# Altium schematic sheet 11_PowerSupply.SchDoc
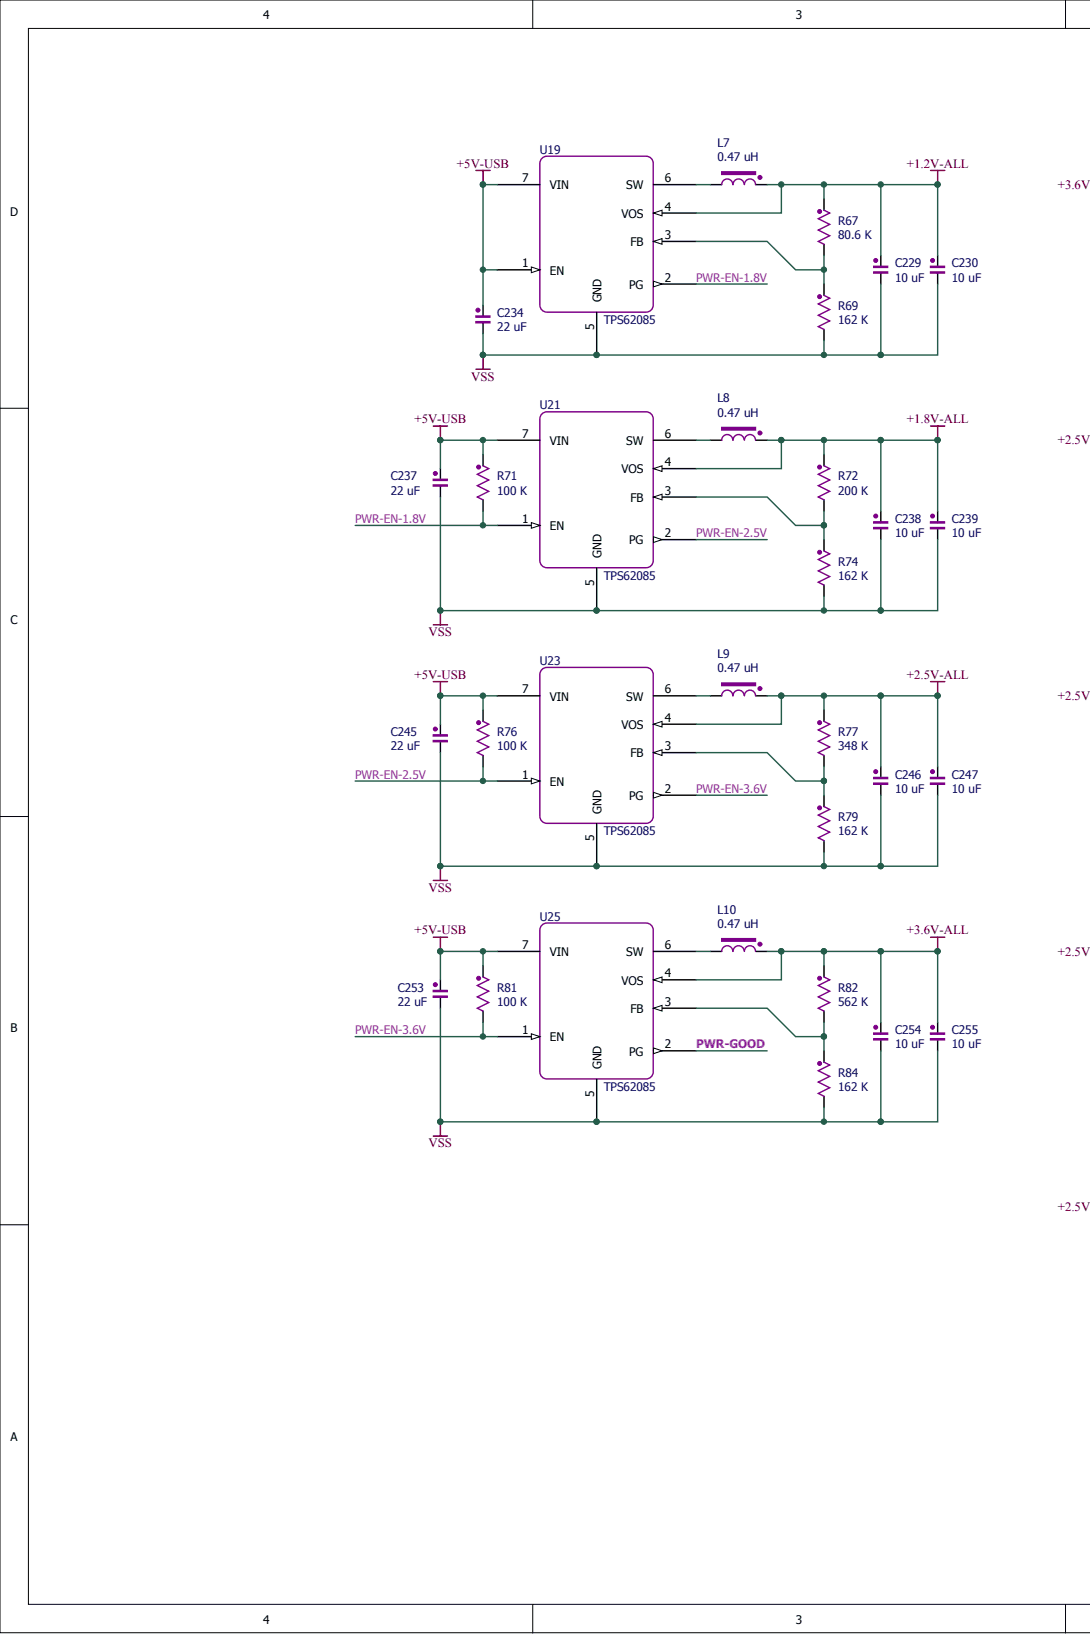
[diagram p0: 11_PowerSupply.SchDoc, left]
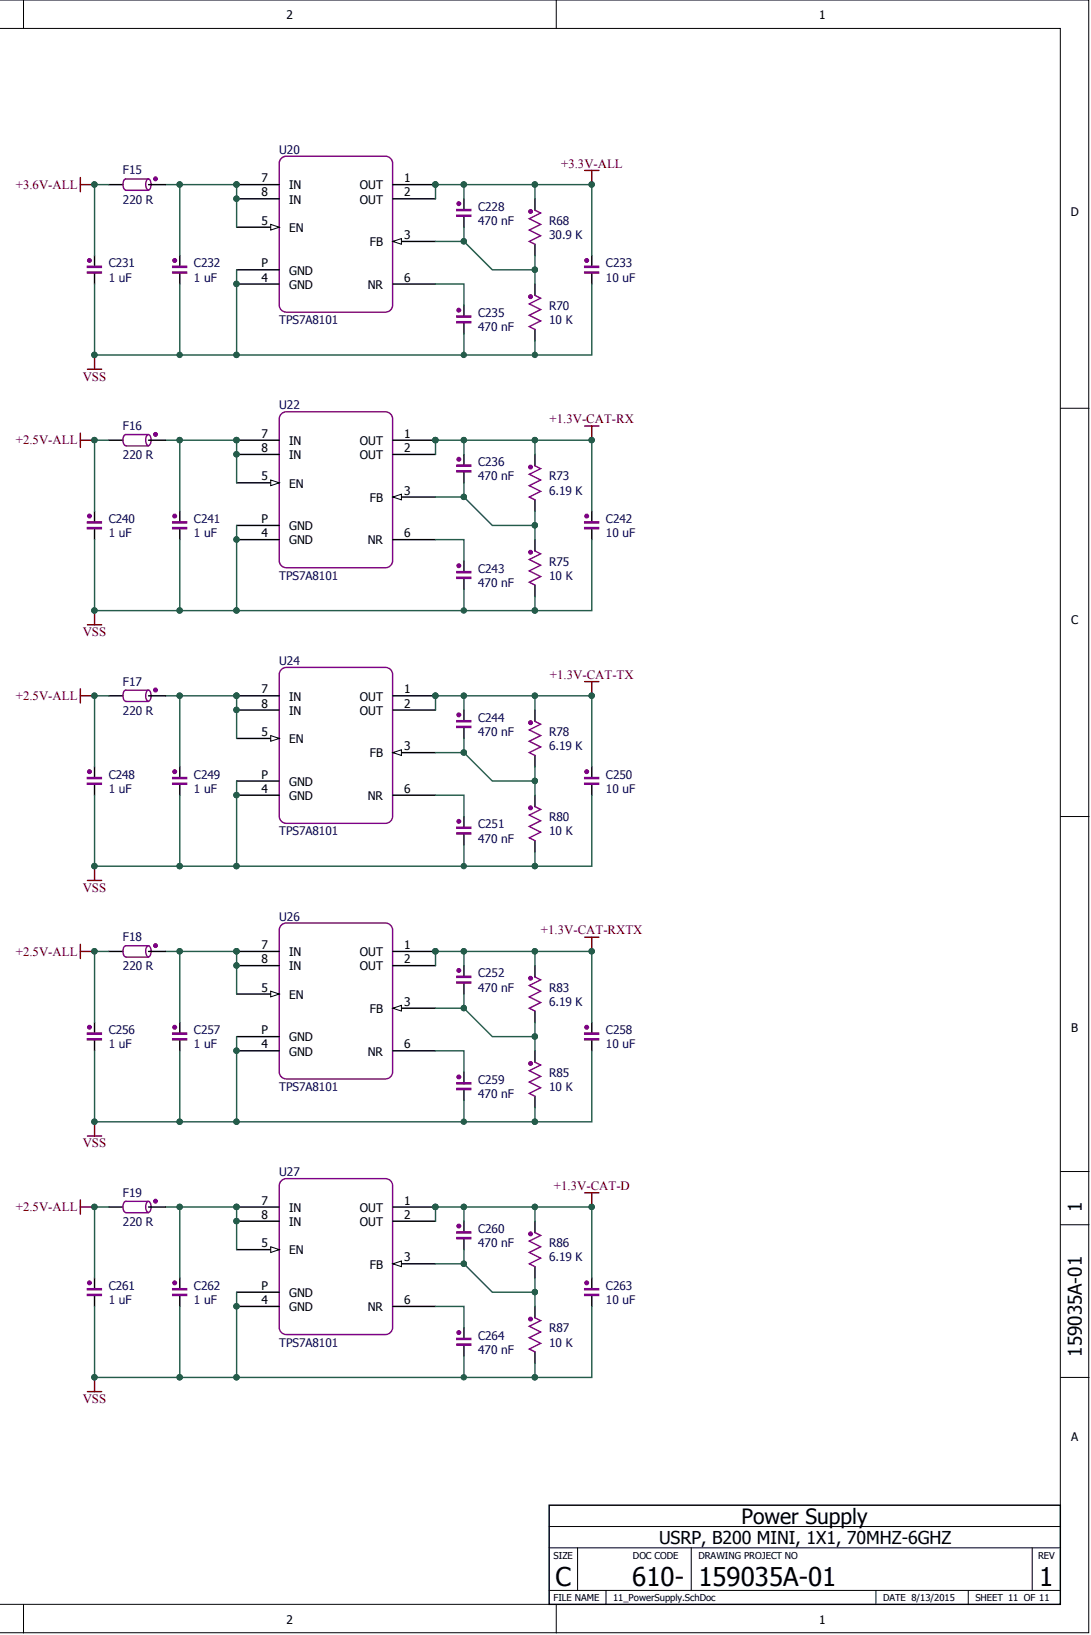
[diagram p1: 11_PowerSupply.SchDoc, right]

## Parts
C228  470 nF  [0201-CAP]
C229  10 uF  [0603-CAP]
C230  10 uF  [0603-CAP]
C231  1 uF  [0402-CAP]
C232  1 uF  [0402-CAP]
C233  10 uF  [0402-CAP]
C234  22 uF  [0805-CAP-HC]
C235  470 nF  [0201-CAP]
C236  470 nF  [0201-CAP]
C237  22 uF  [0805-CAP-HC]
C238  10 uF  [0603-CAP]
C239  10 uF  [0603-CAP]
C240  1 uF  [0402-CAP]
C241  1 uF  [0402-CAP]
C242  10 uF  [0402-CAP]
C243  470 nF  [0201-CAP]
C244  470 nF  [0201-CAP]
C245  22 uF  [0805-CAP-HC]
C246  10 uF  [0603-CAP]
C247  10 uF  [0603-CAP]
C248  1 uF  [0402-CAP]
C249  1 uF  [0402-CAP]
C250  10 uF  [0402-CAP]
C251  470 nF  [0201-CAP]
C252  470 nF  [0201-CAP]
C253  22 uF  [0805-CAP-HC]
C254  10 uF  [0603-CAP]
C255  10 uF  [0603-CAP]
C256  1 uF  [0402-CAP]
C257  1 uF  [0402-CAP]
C258  10 uF  [0402-CAP]
C259  470 nF  [0201-CAP]
C260  470 nF  [0201-CAP]
C261  1 uF  [0402-CAP]
C262  1 uF  [0402-CAP]
C263  10 uF  [0402-CAP]
C264  470 nF  [0201-CAP]
F15  220 R  [0402-BEAD]
F16  220 R  [0402-BEAD]
F17  220 R  [0402-BEAD]
F18  220 R  [0402-BEAD]
F19  220 R  [0402-BEAD]
L7  0.47 uH  [VISHAY-IHLP]
L8  0.47 uH  [VISHAY-IHLP]
L9  0.47 uH  [VISHAY-IHLP]
L10  0.47 uH  [VISHAY-IHLP]
R67  80.6 K  [0201-RES]
R68  30.9 K  [0201-RES]
R69  162 K  [0201-RES]
R70  10 K  [0201-RES]
R71  100 K  [0201-RES]
R72  200 K  [0201-RES]
R73  6.19 K  [0201-RES]
R74  162 K  [0201-RES]
R75  10 K  [0201-RES]
R76  100 K  [0201-RES]
R77  348 K  [0201-RES]
R78  6.19 K  [0201-RES]
R79  162 K  [0201-RES]
R80  10 K  [0201-RES]
R81  100 K  [0201-RES]
R82  562 K  [0201-RES]
R83  6.19 K  [0201-RES]
R84  162 K  [0201-RES]
R85  10 K  [0201-RES]
R86  6.19 K  [0201-RES]
R87  10 K  [0201-RES]
U19  TPS62085  [TI-VSON-7]
U20  TPS7A8101  [TI-SON-8]
U21  TPS62085  [TI-VSON-7]
U22  TPS7A8101  [TI-SON-8]
U23  TPS62085  [TI-VSON-7]
U24  TPS7A8101  [TI-SON-8]
U25  TPS62085  [TI-VSON-7]
U26  TPS7A8101  [TI-SON-8]
U27  TPS7A8101  [TI-SON-8]

## Nets
+1.2V-ALL: C229.2 C230.2 L7.2 R67.2 U19.4(VOS)
+1.3V-CAT-D: C260.2 C263.2 R86.2 U27.1(OUT) U27.2(OUT)
+1.3V-CAT-RX: C236.2 C242.2 R73.2 U22.1(OUT) U22.2(OUT)
+1.3V-CAT-RXTX: C252.2 C258.2 R83.2 U26.1(OUT) U26.2(OUT)
+1.3V-CAT-TX: C244.2 C250.2 R78.2 U24.1(OUT) U24.2(OUT)
+1.8V-ALL: C238.2 C239.2 L8.2 R72.2 U21.4(VOS)
+2.5V-ALL: C240.2 C246.2 C247.2 C248.2 C256.2 C261.2 F16.1 F17.1 F18.1 F19.1 L9.2 R77.2 U23.4(VOS)
+3.3V-ALL: C228.2 C233.2 R68.2 U20.1(OUT) U20.2(OUT)
+3.6V-ALL: C231.2 C254.2 C255.2 F15.1 L10.2 R82.2 U25.4(VOS)
+5V-USB: C234.2 C237.2 C245.2 C253.2 R71.2 R76.2 R81.2 U19.1(EN) U19.7(VIN) U21.7(VIN) U23.7(VIN) U25.7(VIN)
VSS: C229.1 C230.1 C231.1 C232.1 C233.1 C234.1 C235.1 C237.1 C238.1 C239.1 C240.1 C241.1 C242.1 C243.1 C245.1 C246.1 C247.1 C248.1 C249.1 C250.1 C251.1 C253.1 C254.1 C255.1 C256.1 C257.1 C258.1 C259.1 C261.1 C262.1 C263.1 C264.1 R69.1 R70.1 R74.1 R75.1 R79.1 R80.1 R84.1 R85.1 R87.1 U19.5(GND) U20.4(GND) U20.P(GND) U21.5(GND) U22.4(GND) U22.P(GND) U23.5(GND) U24.4(GND) U24.P(GND) U25.5(GND) U26.4(GND) U26.P(GND) U27.4(GND) U27.P(GND)
PWR-EN-1.8V: R71.1 U19.2(PG) U21.1(EN)
PWR-EN-2.5V: R76.1 U21.2(PG) U23.1(EN)
PWR-EN-3.6V: R81.1 U23.2(PG) U25.1(EN)
PWR-GOOD: U25.2(PG)
NetC228_1: C228.1 R68.1 R70.2 U20.3(FB)
NetC232_2: C232.2 F15.2 U20.5(EN) U20.7(IN) U20.8(IN)
NetC235_2: C235.2 U20.6(NR)
NetC236_1: C236.1 R73.1 R75.2 U22.3(FB)
NetC241_2: C241.2 F16.2 U22.5(EN) U22.7(IN) U22.8(IN)
NetC243_2: C243.2 U22.6(NR)
NetC244_1: C244.1 R78.1 R80.2 U24.3(FB)
NetC249_2: C249.2 F17.2 U24.5(EN) U24.7(IN) U24.8(IN)
NetC251_2: C251.2 U24.6(NR)
NetC252_1: C252.1 R83.1 R85.2 U26.3(FB)
NetC257_2: C257.2 F18.2 U26.5(EN) U26.7(IN) U26.8(IN)
NetC259_2: C259.2 U26.6(NR)
NetC260_1: C260.1 R86.1 R87.2 U27.3(FB)
NetC262_2: C262.2 F19.2 U27.5(EN) U27.7(IN) U27.8(IN)
NetC264_2: C264.2 U27.6(NR)
NetL7_1: L7.1 U19.6(SW)
NetL8_1: L8.1 U21.6(SW)
NetL9_1: L9.1 U23.6(SW)
NetL10_1: L10.1 U25.6(SW)
NetR67_1: R67.1 R69.2 U19.3(FB)
NetR72_1: R72.1 R74.2 U21.3(FB)
NetR77_1: R77.1 R79.2 U23.3(FB)
NetR82_1: R82.1 R84.2 U25.3(FB)

## Library data of the parts
C228 C235 C236 C243 C244 C251 C252 C259 C260 C264 = CAP: CAP, 470nF, X5R, 6.3V, ±10%, 0201, PbF; PartNumber: C0201X5R6R3-474KNP; Designer: shyul; PCBMarker: Capacitor; PinCountHole: 0; PinCountSMD: 2; Vendor: VENKEL; Component Kind: Standard; SerialNumber: 0; Value: 470 nF; Version: 1.0; VersionDate: 04-27-2011; Library Name: Basc_1_Passive.IntLib; Publisher: Cowin
C229 C230 C238 C239 C246 C247 C254 C255 = CAP: CAP,SM,10UF,6.3V,20%,X5R,CER,0603,H=.035IN; PartNumber: GRM188R60J106ME47D; Designer: shyul; PCBMarker: Capacitor; PinCountHole: 0; PinCountSMD: 2; SerialNumber: 716465-01; Vendor: muRata; Component Kind: Standard; Value: 10 uF; Version: 1.0; VersionDate: 04-27-2011; Library Name: Basc_1_Passive.IntLib; Publisher: Cowin
C231 C232 C240 C241 C248 C249 C256 C257 C261 C262 = CAP: CAP, 1uF, X5R, 10V, ±10%, 0402, PbF; PartNumber: C0402X5R100-105KNP; Designer: shyul; PCBMarker: Capacitor; PinCountHole: 0; PinCountSMD: 2; Vendor: VENKEL; Component Kind: Standard; SerialNumber: 0; Value: 1 uF; Version: 1.0; VersionDate: 04-27-2011; Library Name: Basc_1_Passive.IntLib; Publisher: Cowin
C233 C242 C250 C258 C263 = CAP: CAP, 10uF, X5R, 6.3V, ±20%, 0402, PbF; PartNumber: C0402X5R6R3-106MNP; Designer: shyul; PCBMarker: Capacitor; PinCountHole: 0; PinCountSMD: 2; Vendor: VENKEL; Component Kind: Standard; SerialNumber: 0; Value: 10 uF; Version: 1.0; VersionDate: 04-27-2011; Library Name: Basc_1_Passive.IntLib; Publisher: Cowin
C234 C237 C245 C253 = CAP: CAP, 22uF, X5R, 25V, ±20%, 0805, PbF; PartNumber: GRM21BR61E226ME44; Designer: shyul; PCBMarker: Capacitor; PinCountHole: 0; PinCountSMD: 2; Vendor: muRata; Component Kind: Standard; SerialNumber: 0; Value: 22 uF; Version: 1.0; VersionDate: 04-27-2011; Library Name: Basc_1_Passive.IntLib; Publisher: Cowin
F15-F19 = BEAD: BEAD, 220R, 700mA, PbF; PartNumber: BLM15EG221SN1; Designer: shyul; PinCountHole: 0; PinCountSMD: 2; Vendor: muRata; Component Kind: Standard; PCBMarker: Bead; SerialNumber: 0; Value: 220 R; Version: 1.0; VersionDate: 04-28-2011; Library Name: Basc_2_EMI_Protection.IntLib; Publisher: Cowin
L7-L10 = COIL: Power Inductor; PartNumber: IHLP1212AEERR47M11; Designer: shyul; PinCountHole: 0; PinCountSMD: 2; Vendor: Vishay; Component Kind: Standard; PCBMarker: Power Inductor; SerialNumber: 0; Value: 0.47 uH; Version: 1.0; VersionDate: 11-06-2014; Library Name: Basc_1_Passive.IntLib; Publisher: Cowin
R67 = RES: RES, 80.6K, ±1%, 1/16W, 0201, PbF; PartNumber: CR0201-20W-8062FT; Designer: shyul; PCBMarker: Resistor; PinCountHole: 0; PinCountSMD: 2; Vendor: VENKEL; Component Kind: Standard; SerialNumber: 0; Value: 80.6 K; Version: 1.0; VersionDate: 04-27-2011; Library Name: Basc_1_Passive.IntLib; Publisher: Cowin
R68 = RES: RES, 30.9K, ±1%, 1/20W, 0201, PbF; PartNumber: CR0201-20W-3092FT; Designer: shyul; PCBMarker: Resistor; PinCountHole: 0; PinCountSMD: 2; Vendor: VENKEL; Component Kind: Standard; SerialNumber: 0; Value: 30.9 K; Version: 1.0; VersionDate: 04-27-2011; Library Name: Basc_1_Passive.IntLib; Publisher: Cowin
R69 R74 R79 R84 = RES: RES, 162K, ±1%, 1/16W, 0201, PbF; PartNumber: CR0201-20W-1623FT; Designer: shyul; PCBMarker: Resistor; PinCountHole: 0; PinCountSMD: 2; Vendor: VENKEL; Component Kind: Standard; SerialNumber: 0; Value: 162 K; Version: 1.0; VersionDate: 04-27-2011; Library Name: Basc_1_Passive.IntLib; Publisher: Cowin
R70 R75 R80 R85 R87 = RES: RES, 10K, ±1%, 1/20W, 0201, PbF; PartNumber: CR0201-20W-1002FT; Designer: shyul; PCBMarker: Resistor; PinCountHole: 0; PinCountSMD: 2; Vendor: VENKEL; Component Kind: Standard; SerialNumber: 0; Value: 10 K; Version: 1.0; VersionDate: 04-27-2011; Library Name: Basc_1_Passive.IntLib; Publisher: Cowin
R71 R76 R81 = RES: RES, 100K, ±1%, 1/20W, 0201, PbF; PartNumber: CR0201-20W-1003FT; Designer: shyul; PCBMarker: Resistor; PinCountHole: 0; PinCountSMD: 2; Vendor: VENKEL; Component Kind: Standard; SerialNumber: 0; Value: 100 K; Version: 1.0; VersionDate: 04-27-2011; Library Name: Basc_1_Passive.IntLib; Publisher: Cowin
R72 = RES: RES, 200K, ±1%, 1/16W, 0201, PbF; PartNumber: CR0201-20W-2003FT; Designer: shyul; PCBMarker: Resistor; PinCountHole: 0; PinCountSMD: 2; Vendor: VENKEL; Component Kind: Standard; SerialNumber: 0; Value: 200 K; Version: 1.0; VersionDate: 04-27-2011; Library Name: Basc_1_Passive.IntLib; Publisher: Cowin
R73 R78 R83 R86 = RES: RES, 6.19K, ±1%, 1/20W, 0201, PbF; PartNumber: CR0201-20W-6191FT; Designer: shyul; PCBMarker: Resistor; PinCountHole: 0; PinCountSMD: 2; Vendor: VENKEL; Component Kind: Standard; SerialNumber: 0; Value: 6.19 K; Version: 1.0; VersionDate: 04-27-2011; Library Name: Basc_1_Passive.IntLib; Publisher: Cowin
R77 = RES: RES, 348K, ±1%, 1/16W, 0201, PbF; PartNumber: CR0201-20W-3483FT; Designer: shyul; PCBMarker: Resistor; PinCountHole: 0; PinCountSMD: 2; Vendor: VENKEL; Component Kind: Standard; SerialNumber: 0; Value: 348 K; Version: 1.0; VersionDate: 04-27-2011; Library Name: Basc_1_Passive.IntLib; Publisher: Cowin
R82 = RES: RES, 562K, ±1%, 1/16W, 0201, PbF; PartNumber: CR0201-20W-5623FT; Designer: shyul; PCBMarker: Resistor; PinCountHole: 0; PinCountSMD: 2; Vendor: VENKEL; Component Kind: Standard; SerialNumber: 0; Value: 562 K; Version: 1.0; VersionDate: 04-27-2011; Library Name: Basc_1_Passive.IntLib; Publisher: Cowin
U19 U21 U23 U25 = TPS62085: 3-A Step-Down Converter with Hiccup Short Circuit Protection in 2x2 QFN Package; PartNumber: TPS62085RLT; Designer: shyul; PCBMarker: Power; PinCountHole: 0; PinCountSMD: 7; Vendor: TI; Component Kind: Standard; SerialNumber: 0; Value: TPS62085; Version: 1.0; VersionDate: 10-24-2014; Library Name: Argon.SchLib; Publisher: Shyu Lee
U20 U22 U24 U26 U27 = TPS7A8101: Low-Noise, Wide-Bandwidth, High PSRR, Low-Dropout 1-A Linear Regulator; PartNumber: TPS7A8101DRB; Designer: shyul; PCBMarker: Power; PinCountHole: 0; PinCountSMD: 8; Vendor: TI; Component Kind: Standard; SerialNumber: 0; Value: TPS7A8101; Version: 1.0; VersionDate: 11-11-2014; Library Name: Argon.SchLib; Publisher: Shyu Lee
